# Altium schematic sheet STM32_PCB_Design.SchDoc(STM32_PCB_Design)
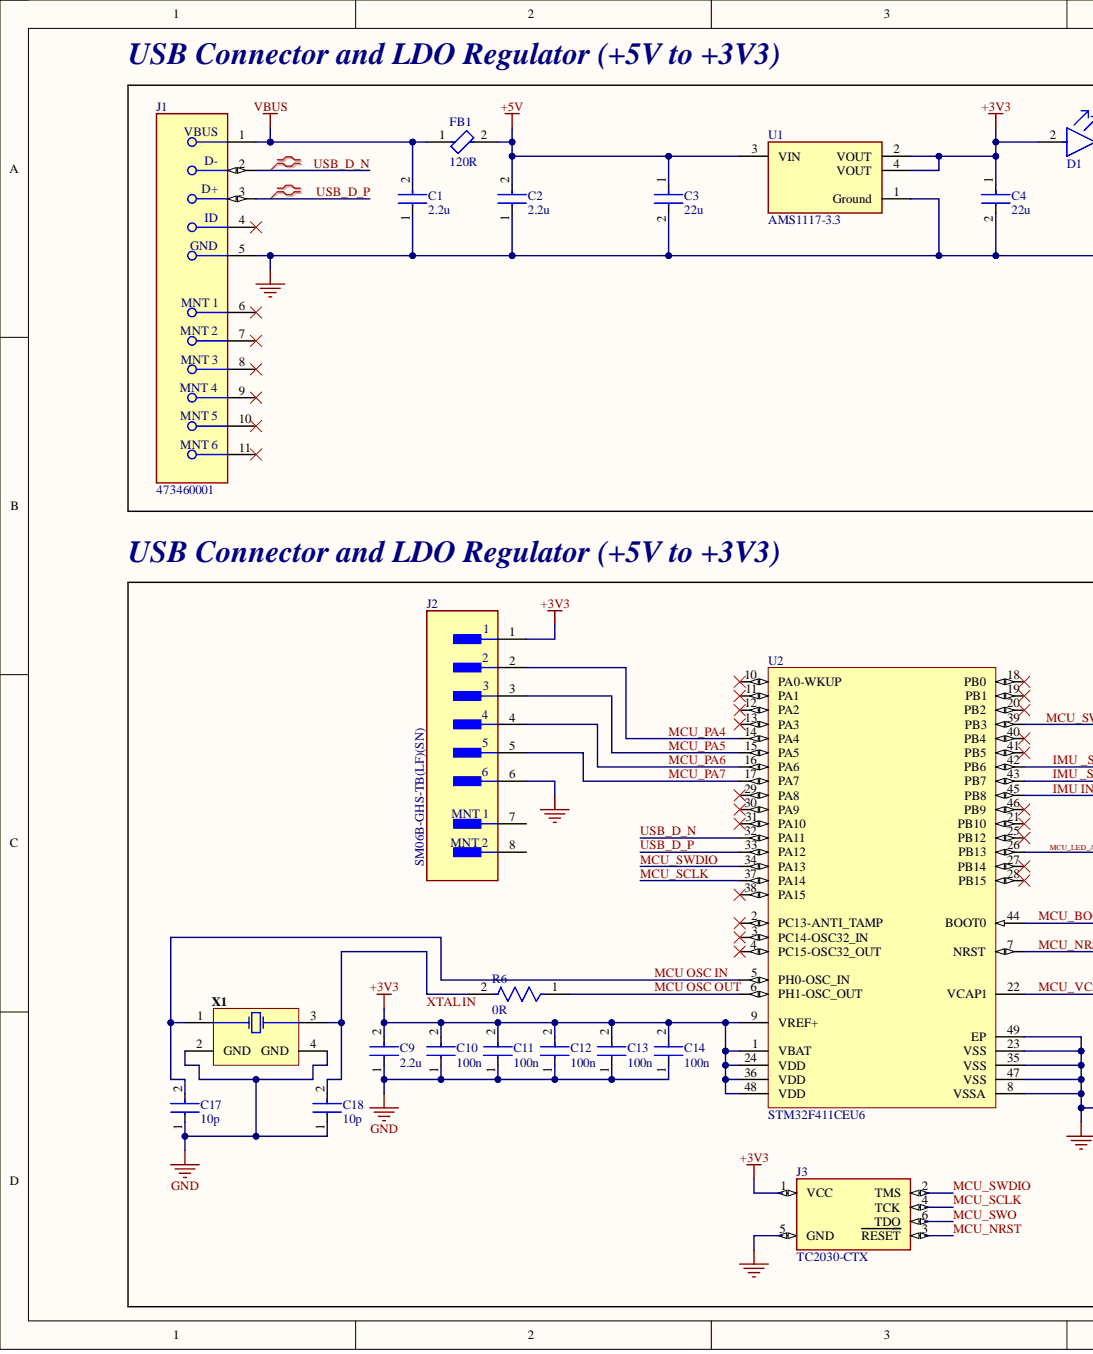
[diagram p0: STM32_PCB_Design.SchDoc, left]
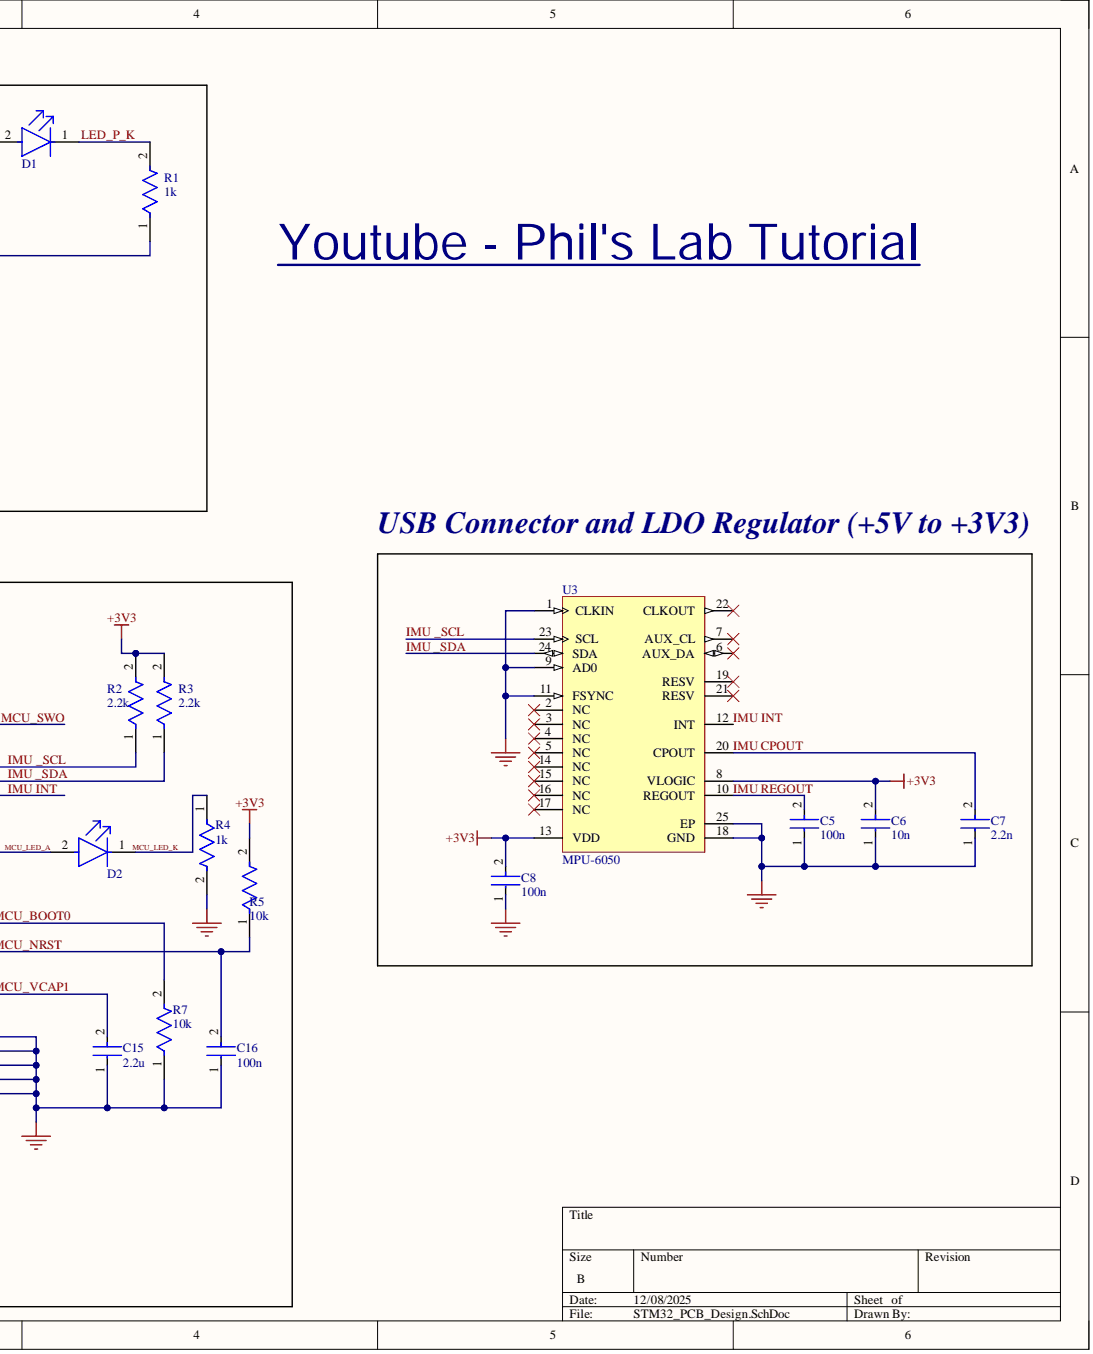
[diagram p1: STM32_PCB_Design.SchDoc, right]

## Parts
C1  2.2u
C2  2.2u
C3  22u
C4  22u
C5  100n
C6  10n
C7  2.2n
C8  100n
C9  2.2u
C10  100n
C11  100n
C12  100n
C13  100n
C14  100n
C15  2.2u
C16  100n
C17  10p
C18  10p
D1  SML-P11MTT86  (value not drawn)
D2  SML-P11UTT86  (value not drawn)
FB1  120R
J1  473460001
J2  SM06B-GHS-TB(LF)(SN)
J3  TC2030-CTX
R1  1k
R2  2.2k
R3  2.2k
R4  1k
R5  10k
R6  0R
R7  10k
U1  AMS1117-3.3
U2  STM32F411CEU6
U3  MPU-6050
X1  TSX-3225 24.0000MF15X-AC3  (value not drawn)

## Nets
+3V3: C4.1 C6.2 C8.2 C9.2 C10.2 C11.2 C12.2 C13.2 C14.2 D1.2 J2.1 J3.1(VCC) R2.2 R3.2 R5.2 U1.2(VOUT) U1.4(VOUT) U2.1(VBAT) U2.9(VREF+) U2.24(VDD) U2.36(VDD) U2.48(VDD) U3.8(VLOGIC) U3.13(VDD)
+5V: C2.2 C3.1 FB1.2 U1.3(VIN)
GND: C1.1 C2.1 C3.2 C4.2 C5.1 C6.1 C7.1 C8.1 C9.1 C10.1 C11.1 C12.1 C13.1 C14.1 C15.1 C16.1 C17.1 C18.1 J1.5 J2.6 J3.5(GND) R1.1 R4.2 R7.1 U1.1(Ground) U2.8(VSSA) U2.23(VSS) U2.35(VSS) U2.47(VSS) U2.49(EP) U3.1(CLKIN) U3.9(AD0) U3.11(FSYNC) U3.18(GND) U3.25(EP) X1.2(GND) X1.4(GND)
VBUS: C1.2 FB1.1 J1.1
IMU _SCL: R2.1 U2.42(PB6) U3.23(SCL)
IMU _SDA: R3.1 U2.43(PB7) U3.24(SDA)
IMU CPOUT: C7.2 U3.20(CPOUT)
IMU INT: U2.45(PB8) U3.12(INT)
IMU REGOUT: C5.2 U3.10(REGOUT)
LED_P_K: D1.1 R1.2
MCU OSC IN: C17.2 U2.5(PH0-OSC_IN) X1.1
MCU OSC OUT: R6.1 U2.6(PH1-OSC_OUT)
MCU_BOOT0: R7.2 U2.44(BOOT0)
MCU_LED_A: D2.2 U2.26(PB13)
MCU_LED_K: D2.1 R4.1
MCU_NRST: C16.2 J3.3(RESET) R5.1 U2.7(NRST)
MCU_PA4: J2.2 U2.14(PA4)
MCU_PA5: J2.3 U2.15(PA5)
MCU_PA6: J2.4 U2.16(PA6)
MCU_PA7: J2.5 U2.17(PA7)
MCU_SCLK: J3.4(TCK) U2.37(PA14)
MCU_SWDIO: J3.2(TMS) U2.34(PA13)
MCU_SWO: J3.6(TDO) U2.39(PB3)
MCU_VCAP1: C15.2 U2.22(VCAP1)
USB_D_N: J1.2 U2.32(PA11)
USB_D_P: J1.3 U2.33(PA12)
XTAL IN: C18.2 R6.2 X1.3

Not connected: J1 4 6 7 8 9 10 11; J2 7 8; U2 2(PC13-ANTI_TAMP) 3(PC14-OSC32_IN) 4(PC15-OSC32_OUT) 10(PA0-WKUP) 11(PA1) 12(PA2) 13(PA3) 18(PB0) 19(PB1) 20(PB2) 21(PB10) 25(PB12) 27(PB14) 28(PB15) 29(PA8) 30(PA9) 31(PA10) 38(PA15) 40(PB4) 41(PB5) 46(PB9); U3 2(NC) 3(NC) 4(NC) 5(NC) 6(AUX_DA) 7(AUX_CL) 14(NC) 15(NC) 16(NC) 17(NC) 19(RESV) 21(RESV) 22(CLKOUT)

## Library data of the parts
C1 C2 C5-C18 = CMP-2100-03641-2: CAP CER 0.1UF 16V X7R 0402; Manufacturer: Murata; Manufacturer Part Number: GRM155R71C104KA88D; Capacitance: 0.10μF; Material: Ceramic; Standoff Height: 0mm; Tolerance: 10%; Value: 0.10μF; Voltage Rating: 16Vdc; Category: Cap; Component Kind: Standard; ComponentLink2Description: Datasheet; ComponentLink2URL: https://search.murata.co.jp/Ceramy/image/img/A01X/G101/ENG/GRM155R71H471KA01-01A.pdf; Device Class L1: Passive Components; Device Class L2: Capacitors; Device Class L3: Ceramic Capacitors; Digikey Description: CAP CER 0.1UF 16V X7R 0402; Height: 0.55mm; Ipc Land Pattern Name: CAPC10050X219; Lead Free: yes; Library Name: Altium Content Vault; Mouser Description: 0402 0.1 uF 16V ±10 % Tolerance X7R SMT Multilayer Ceramic Capacitor; Octopart: https://octopart.com/grm155r71c104ka88d-murata-196880; Package: GRM15; RoHS: TRUE; Temperature: -55°C to +125°C; Temperature Characteristic: X7R; Temperature Range High: +125°C; Temperature Range Low: -55°C
C3 C4 = CMP-2000-05016-2: General Purpose Ceramic Capacitor, 0805, 100nF, 10%, X7R, 0.15, 50V; Dissipation Factor: 2.5%; Insulation Resistance: 100GR; Capacitance: 100nF; Case Code (Imperial): 0805; Case Code (Metric): 2012; Case/Package: 0805; Component Kind: Standard; Depth: 1.25mm; Dielectric: X7R; Dielectric Material: Ceramic; Height: 0.5mm; Lead Free: Lead Free; Length: 2mm; Library Name: Altium Content Vault; Max Operating Temperature: 125°C; Min Operating Temperature: -55°C; Mount: Surface Mount; Number of Pins: 2; Package Quantity: 4000; Packaging: Cut Tape; Radiation Hardening: No; REACH SVHC: No SVHC; RoHS Compliant: Yes; Series: MLCC X7R; Termination: Standard; Thickness: 0.037inch; Tolerance: 10%; Voltage: 50V; Voltage Rating: 50V; Voltage Rating (DC): 50V; Weight: 0.001411oz; Width: 1.2mm
D1 = CMP-2000-07126-2: LED YELLOW/GREEN CLEAR 1006 SMD; Color: Green; Dominant Wavelength: 569nm; Forward Current: 20mA; Forward Voltage: 1.9V; Lens Color: Colorless; Lens Style: Diffused; Luminous Intensity: 2.1mcd; Number of LEDs: 1; Power Rating: 54mW; Test Current: 1mA; Viewing Angle: 150°; Case Code (Imperial): 0402; Case Code (Metric): 1005; Case/Package: 0402; Component Kind: Standard; Height: 0.25mm; Illumination Color: Green; Lead Free: Lead Free; Length: 1mm; Lens Transparency: Clear; Library Name: Altium Content Vault; Max Operating Temperature: 85°C; Min Operating Temperature: -40°C; Mount: Surface Mount; Number of Pins: 2; Package Quantity: 1; Packaging: Tape and Reel; Radiation Hardening: No; REACH SVHC: No SVHC; RoHS Compliant: Yes; Series: PicoLED™; Wavelength: 569nm; Width: 0.6mm
D2 = CMP-08952-000028-1: LED RED CLEAR 1006 SMD; Dominant Wavelength: 621nm; Forward Current: 20mA; Forward Voltage: 1.8V; Lens Color: Colorless; Lens Style: Square; Luminous Intensity: 5.5mcd; Number of LEDs: 1; Test Current: 1mA; Viewing Angle: 150°; Case Code (Imperial): 0402; Case Code (Metric): 1005; Case/Package: 0402; Color: Red; Component Kind: Standard; Height: 0.25mm; Illumination Color: Red; Lead Free: Lead Free; Length: 1mm; Lens Transparency: Clear; Library Name: Altium Content Vault; Max Operating Temperature: 85°C; Min Operating Temperature: -40°C; Mount: Surface Mount; Number of Pins: 2; Package Quantity: 1; Packaging: Tape and Reel; Radiation Hardening: No; REACH SVHC: No SVHC; RoHS Compliant: Yes; Series: PicoLED™; Wavelength: 621nm; Width: 0.6mm
FB1 = CMP-0686-00425-3: Chip Ferrite Bead, 0603, 5Ω @ 100MHz, 0.05Ω, 25%, 800mA; Current Rating: 700mA; DC Resistance (DCR): 50mR; Impedance: 5R; Number of Circuits: 1; Shielding: Unshielded; Case Code (Imperial): 0603; Case Code (Metric): 1608; Case/Package: 0603; Component Kind: Standard; Core Material: Ferrite; DC Current: 700mA; Depth: 0.8mm; Height: 0.8mm; Lead Free: Lead Free; Length: 1.6mm; Library Name: Altium Content Vault; Material: Ferrite; Max Current Rating: 700mA; Max DC Current: 700mA; Max Operating Temperature: 125°C; Military Standard: Not; Min Operating Temperature: -55°C; Number of Lines: 1; Number of Pins: 2; Package Quantity: 1; Packaging: Tape and Reel; Radiation Hardening: No; REACH SVHC: No SVHC; Termination: SMD/SMT; Test Frequency: 100MHz; Tolerance: 25%; Width: 0.8mm
J1 = CMP-2000-05246-2: CONN RCPT USB2.0 MICRO B SMD R/A; Manufacturer: Molex; Conn Orientation: Right Angle; Current Rating: 1.8A; Is Female: yes; Number Of Contacts: 5; Pitch: 0.65mm; Shielding: true; Voltage Rating: 30V; Automotive: No; Category: Conn; Component Kind: Standard; ComponentLink2Description: Datasheet; ComponentLink2URL: https://www.molex.com/webdocs/datasheets/pdf/en-us/0473460001_IO_CONNECTORS.pdf; Contact Material: Gold,Nickel; Device Class L1: Connectors; Device Class L2: USB Connectors; Device Class L3: unset; Digikey Description: CONN RCPT USB2.0 MICRO B SMD R/A; Footprint Url: https://www.molex.com/pdm_docs/sd/473460001_sd.pdf; Height: 2.945mm; Lead Free: Yes; Library Name: Altium Content Vault; Manufacturer Part Number: 473460001; Octopart: https://octopart.com/47346-0001-molex-7164928; Package: 0; RoHS: TRUE; Temperature: -30°C to +85°C; Temperature Range High: +85°C; Temperature Range Low: -30°C; Usb Standard: USB 2.0
J2 = CMP-17439-000061-1: CONN HEADER SMD R/A 6POS 1.25MM; Connector Type: Outer Shroud Contact; Contact Resistance: 50mR; Current Rating: 1A; Max Voltage Rating (AC): 50V; Number of Contacts: 6; Color: Natural; Component Kind: Standard; Contact Material: Bronze; Contact Plating: Tin; Depth: 4.05mm; Fastening Type: Ramp; Housing Color: Beige; Housing Material: Polyamide; Lead Free: Lead Free; Length: 10.75mm; Library Name: Altium Content Vault; Max Current Rating: 1A; Max Operating Temperature: 85°C; Min Operating Temperature: -25°C; Mount: Surface Mount; Number of Positions: 6; Number of Rows: 1; Orientation: Right Angle; Packaging: Tape and Reel; Pitch: 1.25mm; Termination: Solder; Voltage Rating: 50V
J3 = CMP-003-000000-1: CortexÂ® Plug-Of-Nailsâ„¢ Adapter Cable; MF: Tag-Connect LLC; Availability: Unavailable; Component Kind: Standard; Component Type: Standard; Design Item ID: TC2030-CTX; MP: TC2030-CTX; Package: None; Pin Count: 6; Price: None; SnapEDA_Link: https://www.snapeda.com/parts/TC2030-CTX/Tag-Connect%20LLC/view-part/225831/?ref=snap; Source: SnapEDAsch.SchLib; Library Name: Akhila Prabodha
R1-R7 = CMP-2002-08122-2: RES Thick Film, 0Ω, 0.063W, 0402; Max Power Dissipation: 63mW; Resistance: 0mR; Temperature Coefficient: 100ppm/°C; Tolerance: 5%; Voltage Rating: 50V; Case Code (Imperial): 0402; Case Code (Metric): 1005; Case/Package: 0402; Component Kind: Standard; Composition: Thick Film; Contact Plating: Tin; Depth: 0.5mm; Features: Automotive AEC-Q200; Height: 0.35mm; Lead Free: Lead Free; Length: 1mm; Library Name: Altium Content Vault; Max Operating Temperature: 155°C; Military Standard: Not; Min Operating Temperature: -55°C; Mount: Surface Mount; Number of Terminations: 2; Package Quantity: 1; Packaging: Tape and Reel; Power Rating: 63mW; Radiation Hardening: No; Termination: SMD/SMT; Voltage Rating (DC): 50V; Weight: 2.3E-05oz; Width: 0.5mm
U1 = CMP-209535-000001-1: LDO Voltage Regulators 1A, 3.3V; Manufacturer: Advanced Monolithic Systems; Dropout Voltage: 1.1V; Max Junction Temp: +125°C; Max Power Dissipation: 1.2W; Max Supply Voltage: 15V; Nominal Supply Current: 5mA; Number Of Outputs: 1; Output Type: Fixed; Standoff Height: 0.64mm; Automotive: No; Category: IC; Component Kind: Standard; ComponentLink2Description: Datasheet; ComponentLink2URL: http://www.advanced-monolithic.com/pdf/ds1117.pdf; Device Class L1: Integrated Circuits (ICs); Device Class L2: Power Management ICs; Device Class L3: Voltage Regulators - Linear; Height: 1.8mm; Ipc Land Pattern Name: SOT229P700X180-4; Lead Free: Yes; Library Name: Altium Content Vault; Manufacturer Part Number: AMS1117-3.3; Octopart: https://octopart.com/ams1117-3.3-advanced+monolithic+systems-25899300; Output Current: 1A; Output Voltage: 3.3V; Package: AMS1117; RoHS: TRUE; Temperature: -40°C to +125°C; Temperature Range High: +125°C; Temperature Range Low: -40°C
U2 = CMP-0243-00165-1: ARM Cortex-M4 32-bit MCU+FPU, 512 KB Flash, 128 KB RAM, 36 I/Os, 48-Pin UFQFPN, -40 to 85 degC, Tray; FLASH Size (Prog) (kB): 512; I/Os (High Current): 36; Internal RAM Size (kB): 128; Operating Frequency(F) (Processor speed) (MHz): 100; Supply Voltage(Vcc) min (V): 1.7; Component Kind: Standard; ComponentLink1Description: Manufacturer URL; ComponentLink1URL: http://www.st.com/; ComponentLink2Description: Datasheet; ComponentLink2URL: http://www.st.com/st-web-ui/static/active/en/resource/technical/document/datasheet/DM00115249.pdf; Core: ARM Cortex-M4; DatasheetVersion: Rev. 6; Library Name: Altium Content Vault; Mounting Technology: Surface Mount; Package Description: UFQFPN48; Package Reference: 48-Pin Ultra Thin Fine Pitch Quad Flat No-Lead, 7 x 7 x 0.6 mm Body, 0.5 mm Pitch, Exposed Pad; Packing: Tray
U3 = CMP-1753-00002-2: 3-Axis Gyroscope, Accelerometer and a Digital Motion Processor(TM) (DMP), 2.375 to 3.46 V, -40 to 85 degC, 24-Pin QFN, RoHS, Tape and Reel; Manufacturer: InvenSense; Dynamic Catalog: MPU-6000™Series; Featured Product: MPU-60506-Axis,IntegratedI²CSolution; Other Names: 1428-1007-2  MPU6050; Sensor Type: MotionTracking; Category: Sensors,Transducers; Component Kind: Standard; Datasheet URL: http://datasheet.octopart.com/MPU-6050-InvenSense-datasheet-14421344.pdf; Datasheet Version: Rev. 3.3, 05/2012; Family: Multifunction; Library Name: Altium Content Vault; Manufacturer URL: http://www.invensense.com/; Online Catalog: MPU-6000™Series; Output Type: Digital; Standard Package: 5,000; Unit PriceUSD: Digital
X1 = CMP-2000-07333-1: MHz Range Crystal, 24 MHz, -40 to 85 degC, 4-Pin SMD, RoHS, Tape and Reel; Manufacturer: Epson; Brand: EpsonTiming; Drive Level: 200uW; ESR: 40Ohms; Frequency: 24MHz; Frequency Stability: 10PPM; Load Capacitance: 9pF; Unit Weight: 0.000564oz; Component Kind: Standard; Datasheet URL: https://support.epson.biz/td/api/doc_check.php?dl=brief_FA-238V_en.pdf; Datasheet Version: 12/2015; Factory Pack Quantity: 250; Height: 0.6mm; Length: 3.2mm; Library Name: Altium Content Vault; Manufacturer URL: https://www.eea.epson.com/; Mounting Style: SMD/SMT; Mounting Technology: SurfaceMount; Operating Temperature Range: -40Cto+85C; Package / Case: 3.2mmx2.5mm; Package Description: 4-Pin Surface Mount Device, Body 3.2 x 2.5 mm; Package Reference: TSX-3225; Packaging: Reel; Product Category: Crystals; RoHS: True; Series: TSX-3225; Termination Style: SMD/SMT; Tolerance: 10PPM; Type: Crystal; Width: 2.5mm
